# Altium schematic sheet FPGA_main.SchDoc
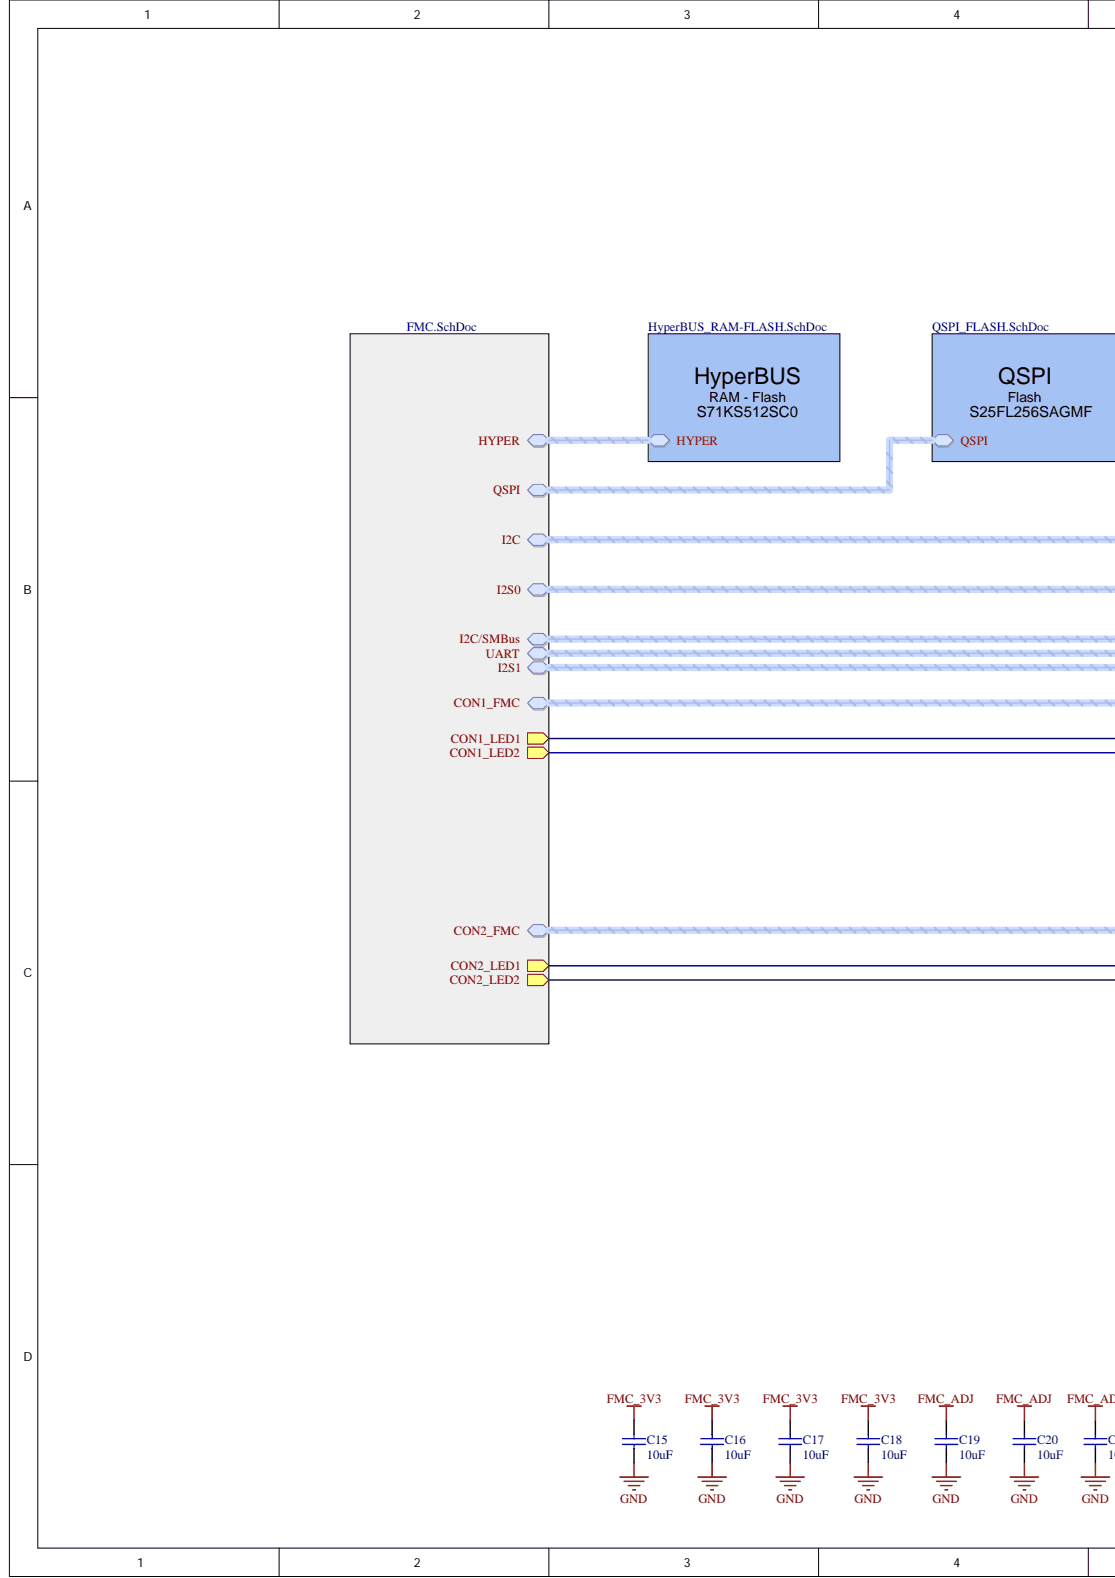
[diagram p0: FPGA_main.SchDoc, left]
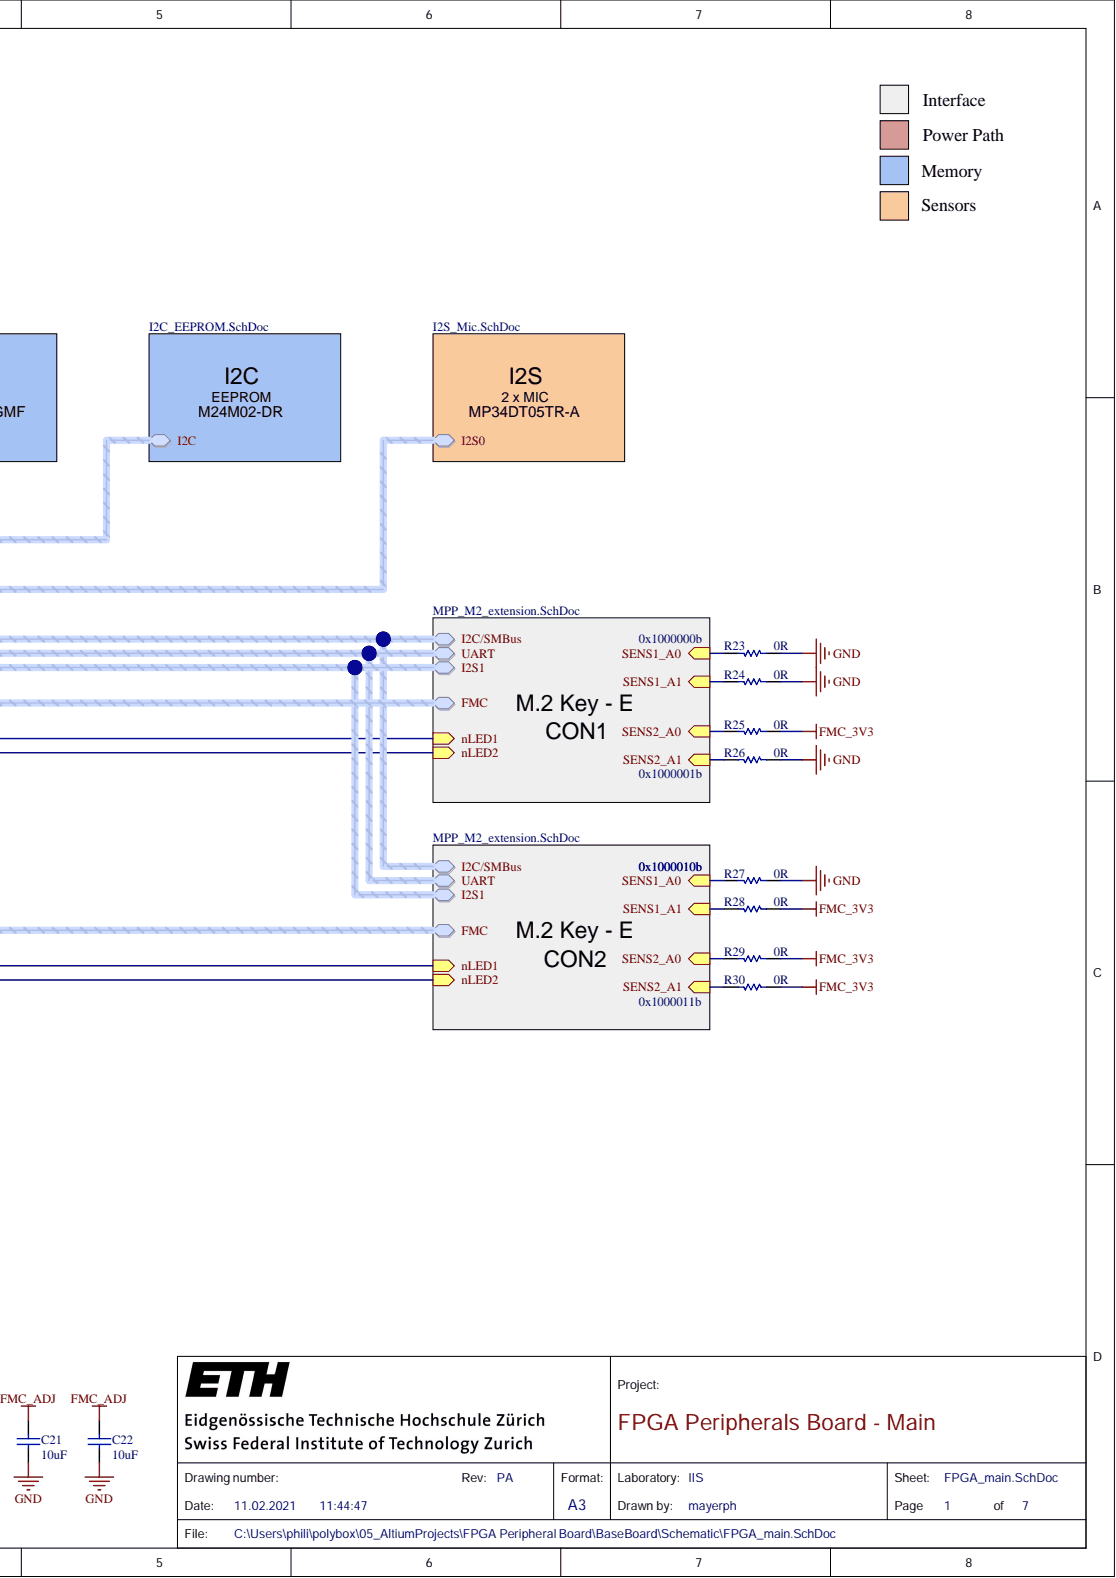
[diagram p1: FPGA_main.SchDoc, right]

## Parts
C15  10uF  [CAPC1608X90X35LL15T15]
C16  10uF  [CAPC1608X90X35LL15T15]
C17  10uF  [CAPC1608X90X35LL15T15]
C18  10uF  [CAPC1608X90X35LL15T15]
C19  10uF  [CAPC1608X90X35LL15T15]
C20  10uF  [CAPC1608X90X35LL15T15]
C21  10uF  [CAPC1608X90X35LL15T15]
C22  10uF  [CAPC1608X90X35LL15T15]
R23  0R  [RESC1005X40X25LL05T10]
R24  0R  [RESC1005X40X25LL05T10]
R25  0R  [RESC1005X40X25LL05T10]
R26  0R  [RESC1005X40X25LL05T10]
R27  0R  [RESC1005X40X25LL05T10]
R28  0R  [RESC1005X40X25LL05T10]
R29  0R  [RESC1005X40X25LL05T10]
R30  0R  [RESC1005X40X25LL05T10]

## Nets
FMC_3V3: C15.2 C16.2 C17.2 C18.2 R25.1 R28.1 R29.1 R30.1
FMC_ADJ: C19.2 C20.2 C21.2 C22.2
GND: C15.1 C16.1 C17.1 C18.1 C19.1 C20.1 C21.1 C22.1 R23.1 R24.1 R26.1 R27.1
NetR23_2: R23.2
NetR24_2: R24.2
NetR25_2: R25.2
NetR26_2: R26.2
NetR27_2: R27.2
NetR28_2: R28.2
NetR29_2: R29.2
NetR30_2: R30.2
CON1_FMC.FMC_GP_D_N0:
CON1_FMC.FMC_GP_D_N1:
CON1_FMC.FMC_GP_D_N2:
CON1_FMC.FMC_GP_D_N3:
CON1_FMC.FMC_GP_D_N4:
CON1_FMC.FMC_GP_D_N5:
CON1_FMC.FMC_GP_D_N6:
CON1_FMC.FMC_GP_D_N7:
CON1_FMC.FMC_GP_D_P0:
CON1_FMC.FMC_GP_D_P1:
CON1_FMC.FMC_GP_D_P2:
CON1_FMC.FMC_GP_D_P3:
CON1_FMC.FMC_GP_D_P4:
CON1_FMC.FMC_GP_D_P5:
CON1_FMC.FMC_GP_D_P6:
CON1_FMC.FMC_GP_D_P7:
CON1_FMC.FMC_GP_S0:
CON1_FMC.FMC_GP_S1:
CON1_FMC.FMC_GP_S2:
CON1_FMC.FMC_GP_S3:
CON1_FMC.FMC_GP_S4:
CON1_FMC.FMC_GP_S5:
CON1_FMC.FMC_GP_S6:
CON1_FMC.FMC_GP_S7:
CON1_LED1:
CON1_LED2:
CON2_FMC.FMC_GP_D_N0:
CON2_FMC.FMC_GP_D_N1:
CON2_FMC.FMC_GP_D_N2:
CON2_FMC.FMC_GP_D_N3:
CON2_FMC.FMC_GP_D_N4:
CON2_FMC.FMC_GP_D_N5:
CON2_FMC.FMC_GP_D_N6:
CON2_FMC.FMC_GP_D_N7:
CON2_FMC.FMC_GP_D_P0:
CON2_FMC.FMC_GP_D_P1:
CON2_FMC.FMC_GP_D_P2:
CON2_FMC.FMC_GP_D_P3:
CON2_FMC.FMC_GP_D_P4:
CON2_FMC.FMC_GP_D_P5:
CON2_FMC.FMC_GP_D_P6:
CON2_FMC.FMC_GP_D_P7:
CON2_FMC.FMC_GP_S0:
CON2_FMC.FMC_GP_S1:
CON2_FMC.FMC_GP_S2:
CON2_FMC.FMC_GP_S3:
CON2_FMC.FMC_GP_S4:
CON2_FMC.FMC_GP_S5:
CON2_FMC.FMC_GP_S6:
CON2_FMC.FMC_GP_S7:
CON2_LED1:
CON2_LED2:
HYPER.HYPER_CK_N:
HYPER.HYPER_CK_P:
HYPER.HYPER_CSN0:
HYPER.HYPER_CSN1:
HYPER.HYPER_D0:
HYPER.HYPER_D1:
HYPER.HYPER_D2:
HYPER.HYPER_D3:
HYPER.HYPER_D4:
HYPER.HYPER_D5:
HYPER.HYPER_D6:
HYPER.HYPER_D7:
HYPER.HYPER_RWDS:
I2C/SMBus.I2C_SCL:
I2C/SMBus.I2C_SDA:
I2C/SMBus.nALERT:
I2S0.I2S_CLK:
I2S0.I2S_OUT:
UART.UART_RX:
UART.UART_TX:

## Library data of the parts
C15-C22 = CMP-2006-04476-1: Manufacturer: AVX Interconnect / Elco; Manufacturer Part Number: 06036D106MAT2A; Dielectric: X5R; Dielectric Material: Ceramic; Tolerance: 20%; Voltage: 6.3V; Case Code (Imperial): 0603; Case Code (Metric): 1608; Case/Package: 0603; Category: Ceramic Capacitors; Component Kind: Standard; ComponentLink1Description: https://datasheet.ciiva.com/153/cx5r-153301.pdf?src-supplier=Digi-Key; ComponentLink1URL: https://datasheet.ciiva.com/153/cx5r-153301.pdf?src-supplier=Digi-Key; ComponentLink2Description: https://datasheet.ciiva.com/1458/1796776-1458117.pdf?src-supplier=Element14; ComponentLink2URL: https://datasheet.ciiva.com/1458/1796776-1458117.pdf?src-supplier=Element14; ComponentLink3Description: https://datasheet.ciiva.com/153/cx5r-153302.pdf?src-supplier=Digi-Key; ComponentLink3URL: https://datasheet.ciiva.com/153/cx5r-153302.pdf?src-supplier=Digi-Key; ComponentLink4Description: https://datasheet.ciiva.com/153/cx5r-153303.pdf?src-supplier=Digi-Key; ComponentLink4URL: https://datasheet.ciiva.com/153/cx5r-153303.pdf?src-supplier=Digi-Key; ComponentLink5Description: https://datasheet.ciiva.com/5422/cx5r-33896-5422935.pdf?src-supplier=Mouser; ComponentLink5URL: https://datasheet.ciiva.com/5422/cx5r-33896-5422935.pdf?src-supplier=Mouser; ComponentLink6Description: https://datasheet.ciiva.com/16538/cx5r-16538025.pdf?src-supplier=Avnet+Asia; ComponentLink6URL: https://datasheet.ciiva.com/16538/cx5r-16538025.pdf?src-supplier=Avnet+Asia; ComponentLink7Description: https://datasheet.ciiva.com/2987/cx5r-2987866.pdf?src-supplier=Avnet; ComponentLink7URL: https://datasheet.ciiva.com/2987/cx5r-2987866.pdf?src-supplier=Avnet; ComponentLink8Description: https://datasheet.ciiva.com/14591/70001028-14591754.pdf?src-supplier=Allied+Electronics; ComponentLink8URL: https://datasheet.ciiva.com/14591/70001028-14591754.pdf?src-supplier=Allied+Electronics; ComponentLink9Description: https://datasheet.ciiva.com/2987/cx5r-2987867.pdf?src-supplier=Avnet; ComponentLink9URL: https://datasheet.ciiva.com/2987/cx5r-2987867.pdf?src-supplier=Avnet; ComponentLink10Description: https://datasheet.ciiva.com/16201/cx5r-16201627.pdf?src-supplier=Future+Electronics; ComponentLink10URL: https://datasheet.ciiva.com/16201/cx5r-16201627.pdf?src-supplier=Future+Electronics; Construction: Flat; Contact Plating: Tin,Matte; Depth: 0.81mm; Height: 0.9mm; Lead Free: Lead Free; Length: 1.6mm; Library Name: Altium Content Vault; Max Operating Temperature: 85°C; Min Operating Temperature: -55°C; Mount: Surface Mount,PCB; Number of Pins: 2; Package Quantity: 1; Packaging: Tape and Reel; Part Number: 06036D106MAT2A; Pricing 1: 1=0.24000, 10=0.16500, 50=0.09900, 100=0.08360, 500=0.05720, 1000=0.05060 (USD); Radiation Hardening: No; REACH SVHC: No SVHC; RoHS: Yes; RoHS Compliant: Yes; Series: -; Stock 1: 1934840; Supplier 1: Digi-Key; Supplier Part Number 1: 478-5318-1-ND; Termination: SMD/SMT; Thickness: 0.035inch; Value: 10uF; Voltage Rating: 6.3V; Voltage Rating (DC): 6.3V; Width: 0.032inch
R23-R30 = CMP-2002-07912-1: Manufacturer: Yageo; Manufacturer Part Number: RC0402JR-070RL; Max Power Dissipation: 63mW; Power Rating: 62.5mW; Temperature Coefficient: 200ppm/°C; Tolerance: 5%; Value: 0 Ohm; Voltage Rating: 50V; Case Code (Imperial): 0402; Case Code (Metric): 1005; Case/Package: 0402; Category: Chip SMD Resistors; Component Kind: Standard; ComponentLink1Description: https://datasheet.ciiva.com/2452/pyu-rc0402-51-rohs-l-6-2452608.pdf?src-supplier=Digi-Key; ComponentLink1URL: https://datasheet.ciiva.com/2452/pyu-rc0402-51-rohs-l-6-2452608.pdf?src-supplier=Digi-Key; ComponentLink2Description: https://datasheet.ciiva.com/11808/0900766b80c66297-11808195.pdf?src-supplier=RSComponents; ComponentLink2URL: https://datasheet.ciiva.com/11808/0900766b80c66297-11808195.pdf?src-supplier=RSComponents; ComponentLink3Description: https://datasheet.ciiva.com/2452/pyu-r-int-thick-7-2452609.pdf?src-supplier=Digi-Key; ComponentLink3URL: https://datasheet.ciiva.com/2452/pyu-r-int-thick-7-2452609.pdf?src-supplier=Digi-Key; ComponentLink4Description: https://datasheet.ciiva.com/2452/pyu-r-int-thick-7-2452610.pdf?src-supplier=Digi-Key; ComponentLink4URL: https://datasheet.ciiva.com/2452/pyu-r-int-thick-7-2452610.pdf?src-supplier=Digi-Key; ComponentLink5Description: https://datasheet.ciiva.com/8896/pyu-rc0402-51-rohs-l-6-8896201.pdf?src-supplier=Avnet; ComponentLink5URL: https://datasheet.ciiva.com/8896/pyu-rc0402-51-rohs-l-6-8896201.pdf?src-supplier=Avnet; ComponentLink6Description: https://datasheet.ciiva.com/28386/pyu-rc0402-51-rohs-l-6-28386633.pdf?src-supplier=Avnet+Europe; ComponentLink6URL: https://datasheet.ciiva.com/28386/pyu-rc0402-51-rohs-l-6-28386633.pdf?src-supplier=Avnet+Europe; ComponentLink7Description: https://datasheet.ciiva.com/22747/1018594-22747515.pdf?src-supplier=Element14; ComponentLink7URL: https://datasheet.ciiva.com/22747/1018594-22747515.pdf?src-supplier=Element14; ComponentLink8Description: https://datasheet.ciiva.com/15189/getdatasheetpartid-326379-15189533.pdf?src-supplier=Verical; ComponentLink8URL: https://datasheet.ciiva.com/15189/getdatasheetpartid-326379-15189533.pdf?src-supplier=Verical; ComponentLink9Description: https://datasheet.ciiva.com/7983/1018594-7983535.pdf?src-supplier=Element14; ComponentLink9URL: https://datasheet.ciiva.com/7983/1018594-7983535.pdf?src-supplier=Element14; Contact Plating: Tin,Matte; Depth: 0.5mm; Features: Moisture Resistant; Height: 0.016inch; Lead Free: Lead Free; Length: 1mm; Library Name: Altium Content Vault; Max Operating Temperature: 155°C; Military Standard: Not; Min Operating Temperature: -55°C; Number of Terminations: 2; Package Quantity: 1; Packaging: Tape and Reel; Part Number: RC0402JR-070RL; Pricing 1: 1=0.10000, 10=0.01200, 25=0.00840, 50=0.00640, 100=0.00480, 250=0.00364, 500=0.00292, 1000=0.00215, 5000=0.00154 (USD); Radiation Hardening: No; REACH SVHC: Unknown; Resistor Type: Thick Film; RoHS: Yes; Stock 1: 44193294; Supplier 1: Digi-Key; Supplier Part Number 1: 311-0.0JRCT-ND; Termination: SMD/SMT; Width: 0.02inch
